# Altium schematic sheet PowerSupply.SchDoc
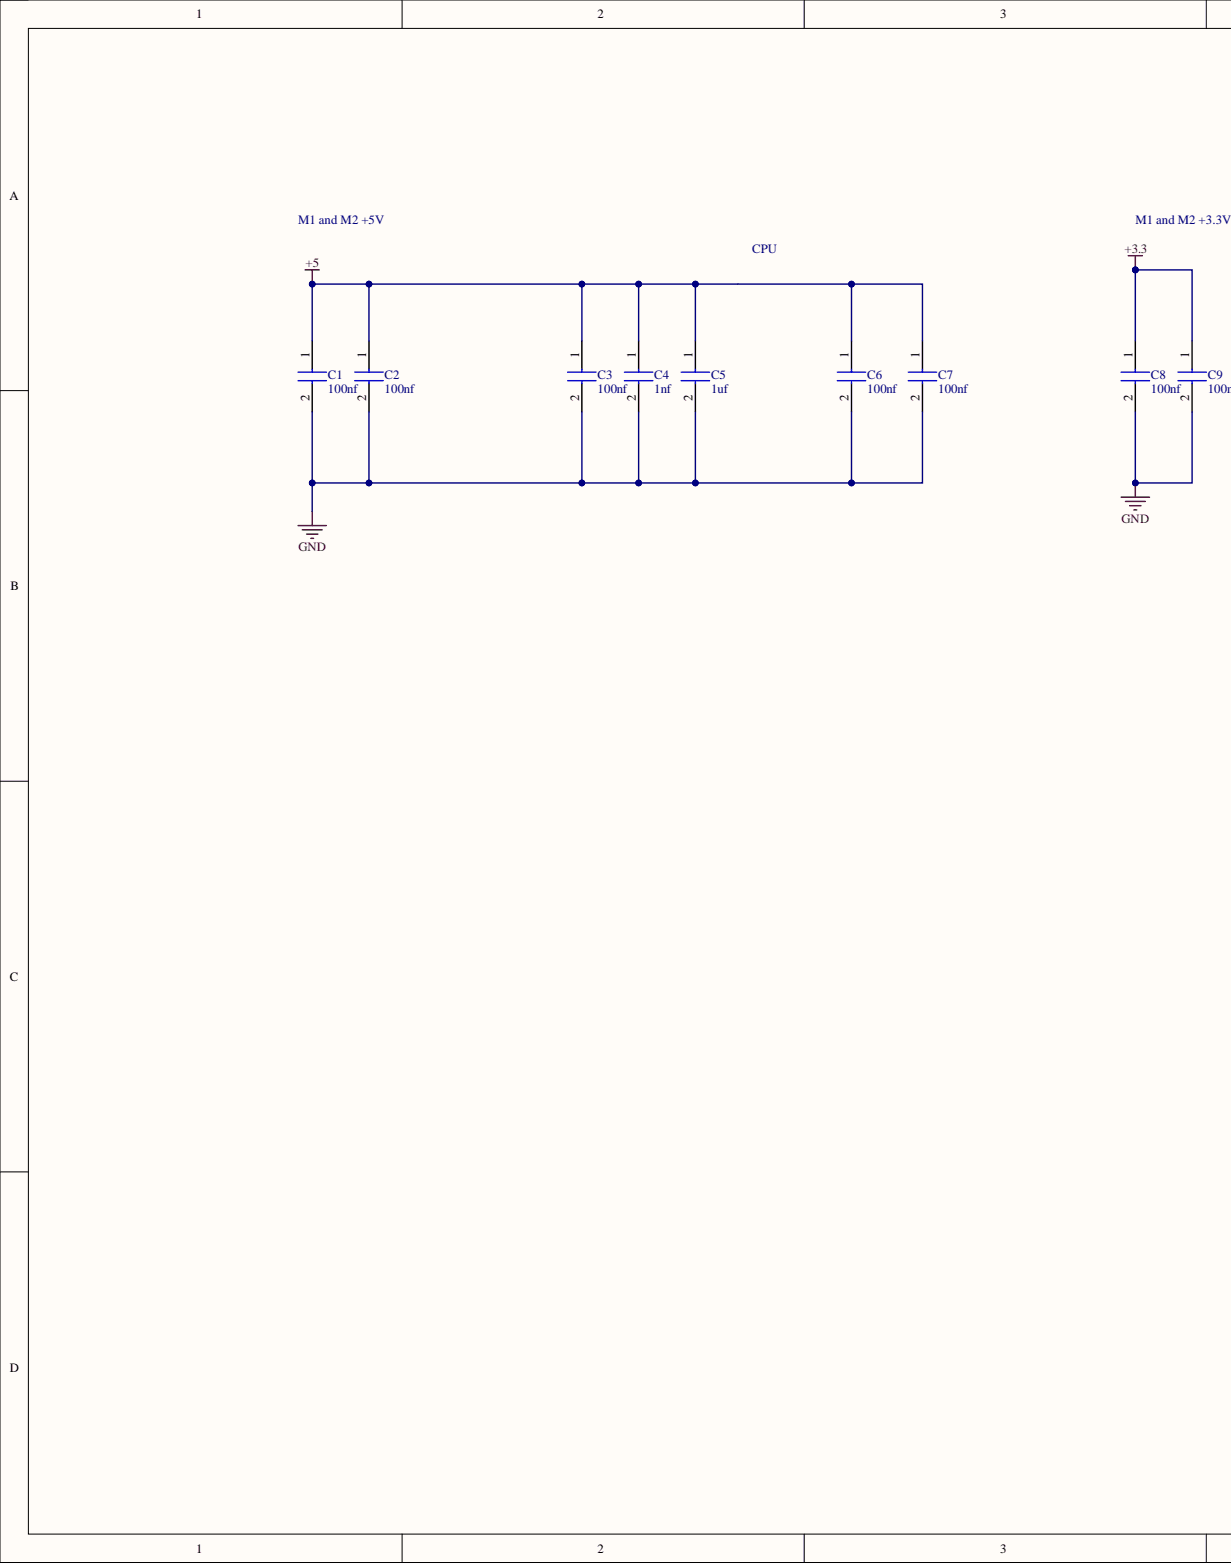
[diagram p0: PowerSupply.SchDoc, left]
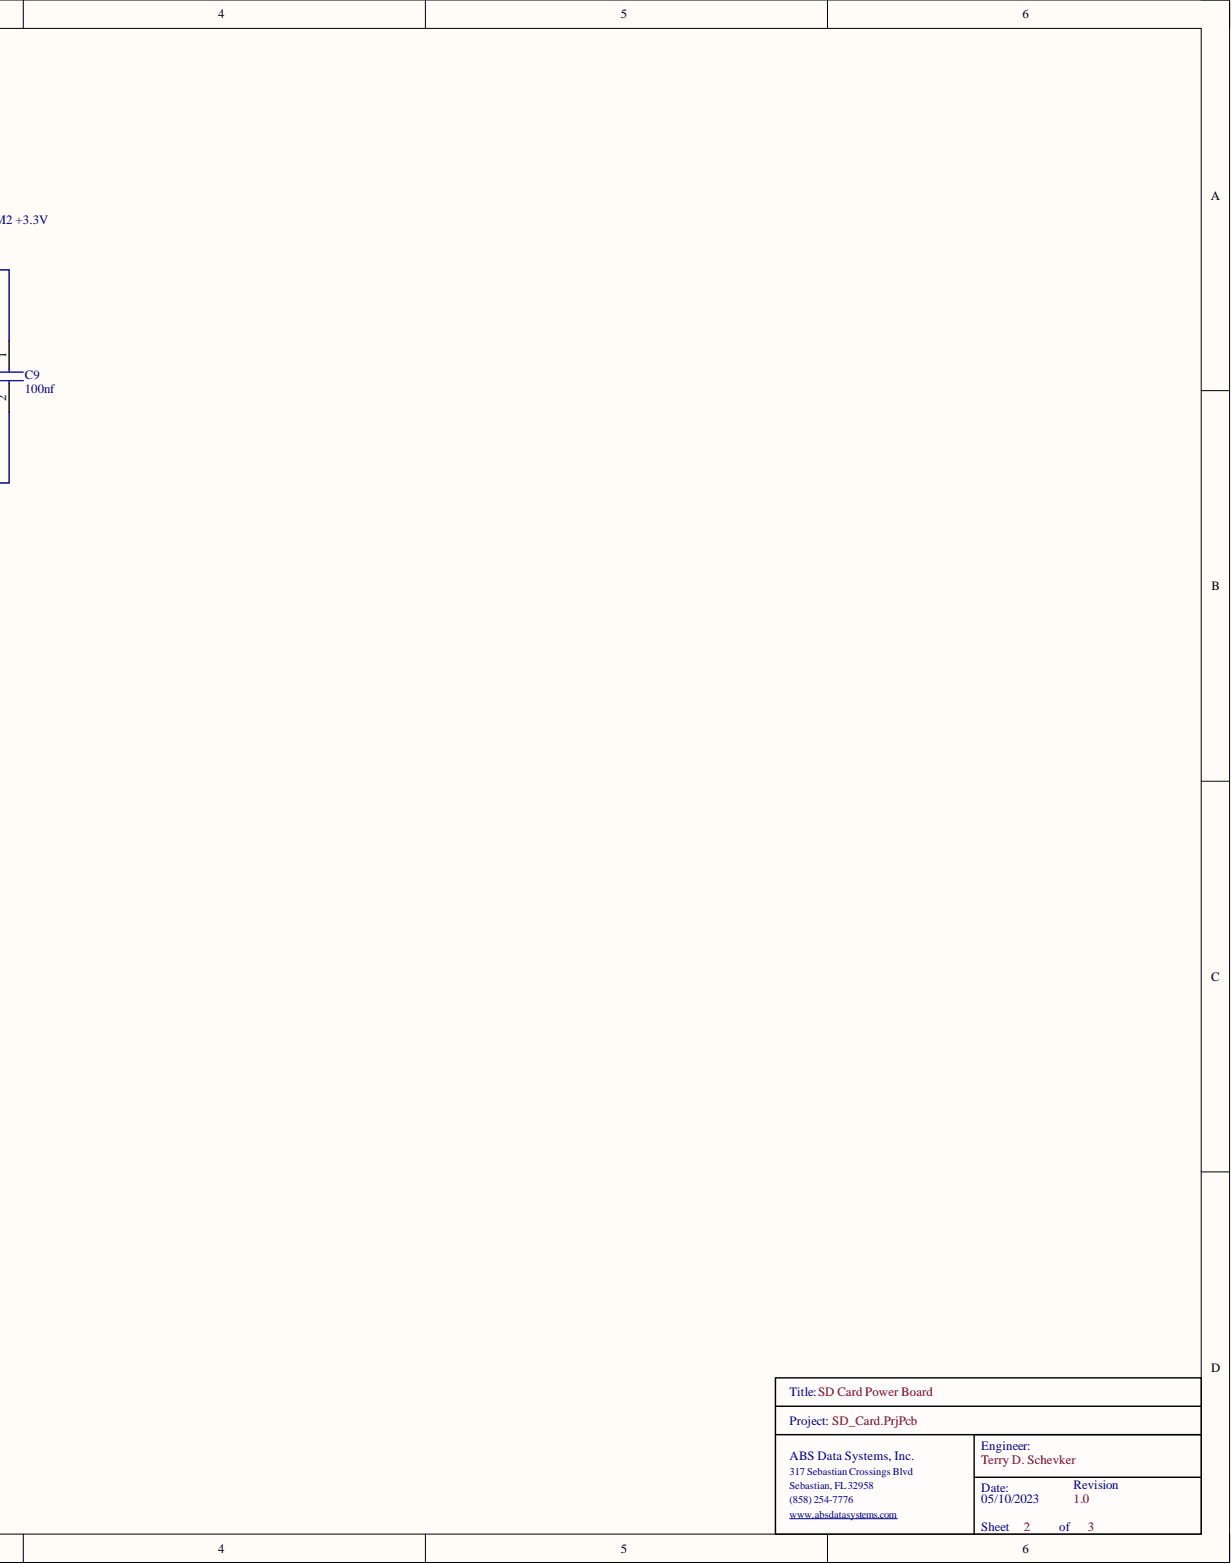
[diagram p1: PowerSupply.SchDoc, right]

## Parts
C1  100nf  [0603]
C2  100nf  [0603]
C3  100nf  [0603]
C4  1nf  [0603]
C5  1uf  [0603]
C6  100nf  [0603]
C7  100nf  [0603]
C8  100nf  [0603]
C9  100nf  [0603]

## Nets
+3.3: C8.1 C9.1
+5: C1.1 C2.1 C3.1 C4.1 C5.1 C6.1 C7.1
GND: C1.2 C2.2 C3.2 C4.2 C5.2 C6.2 C7.2 C8.2 C9.2

## Library data of the parts
C1-C3 C6-C9 = CL10B104JB8NNNC: Samsung Electro-Mechanics 0603 100nf; Component Kind: Standard; Library Name: Capacitors.SchLib
C4 = CL10B102KB8NNNL: Samsung Electro-Mechanics 0603 1nf; Component Kind: Standard; Library Name: Capacitors.SchLib
C5 = CL10B105KA8NNNL: Samsung Electro-Mechanics 0603 1uf; Component Kind: Standard; Library Name: Capacitors.SchLib
